# Altium schematic sheet H-9220 Backplane Board - DUT Bd9-10 (REV07).SchDoc
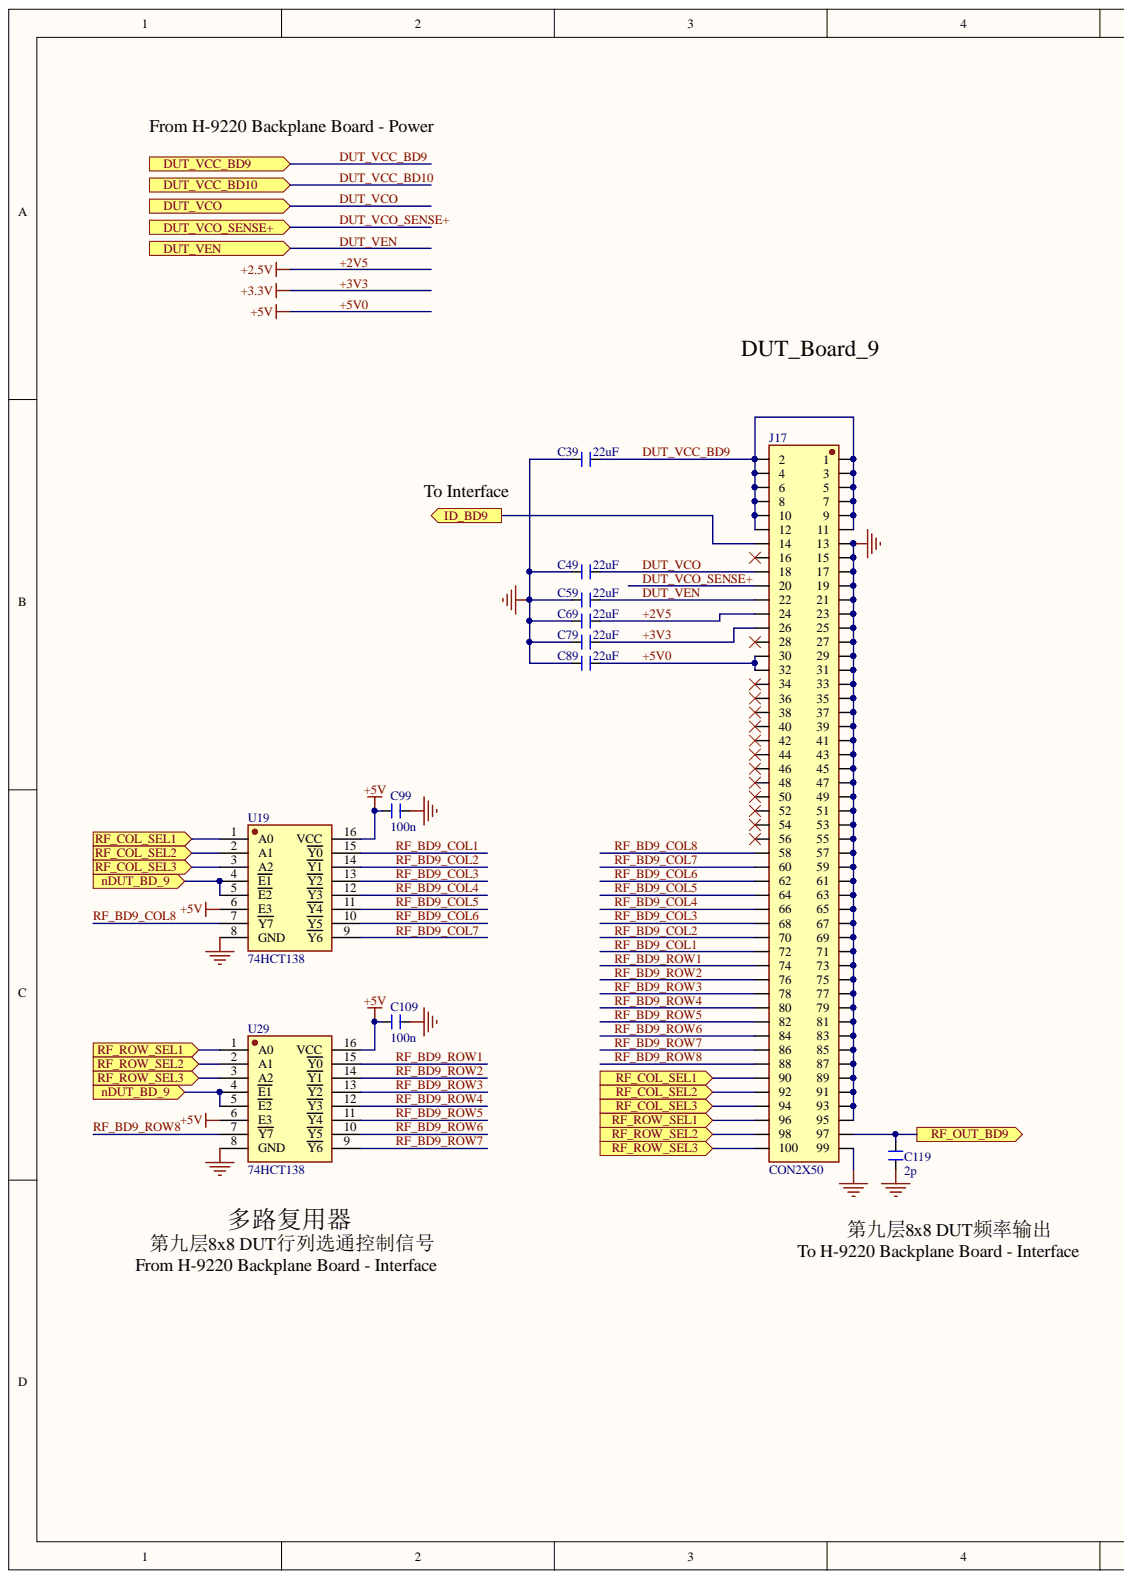
[diagram p0: H-9220 Backplane Board - DUT Bd9-10 (REV07).SchDoc, left]
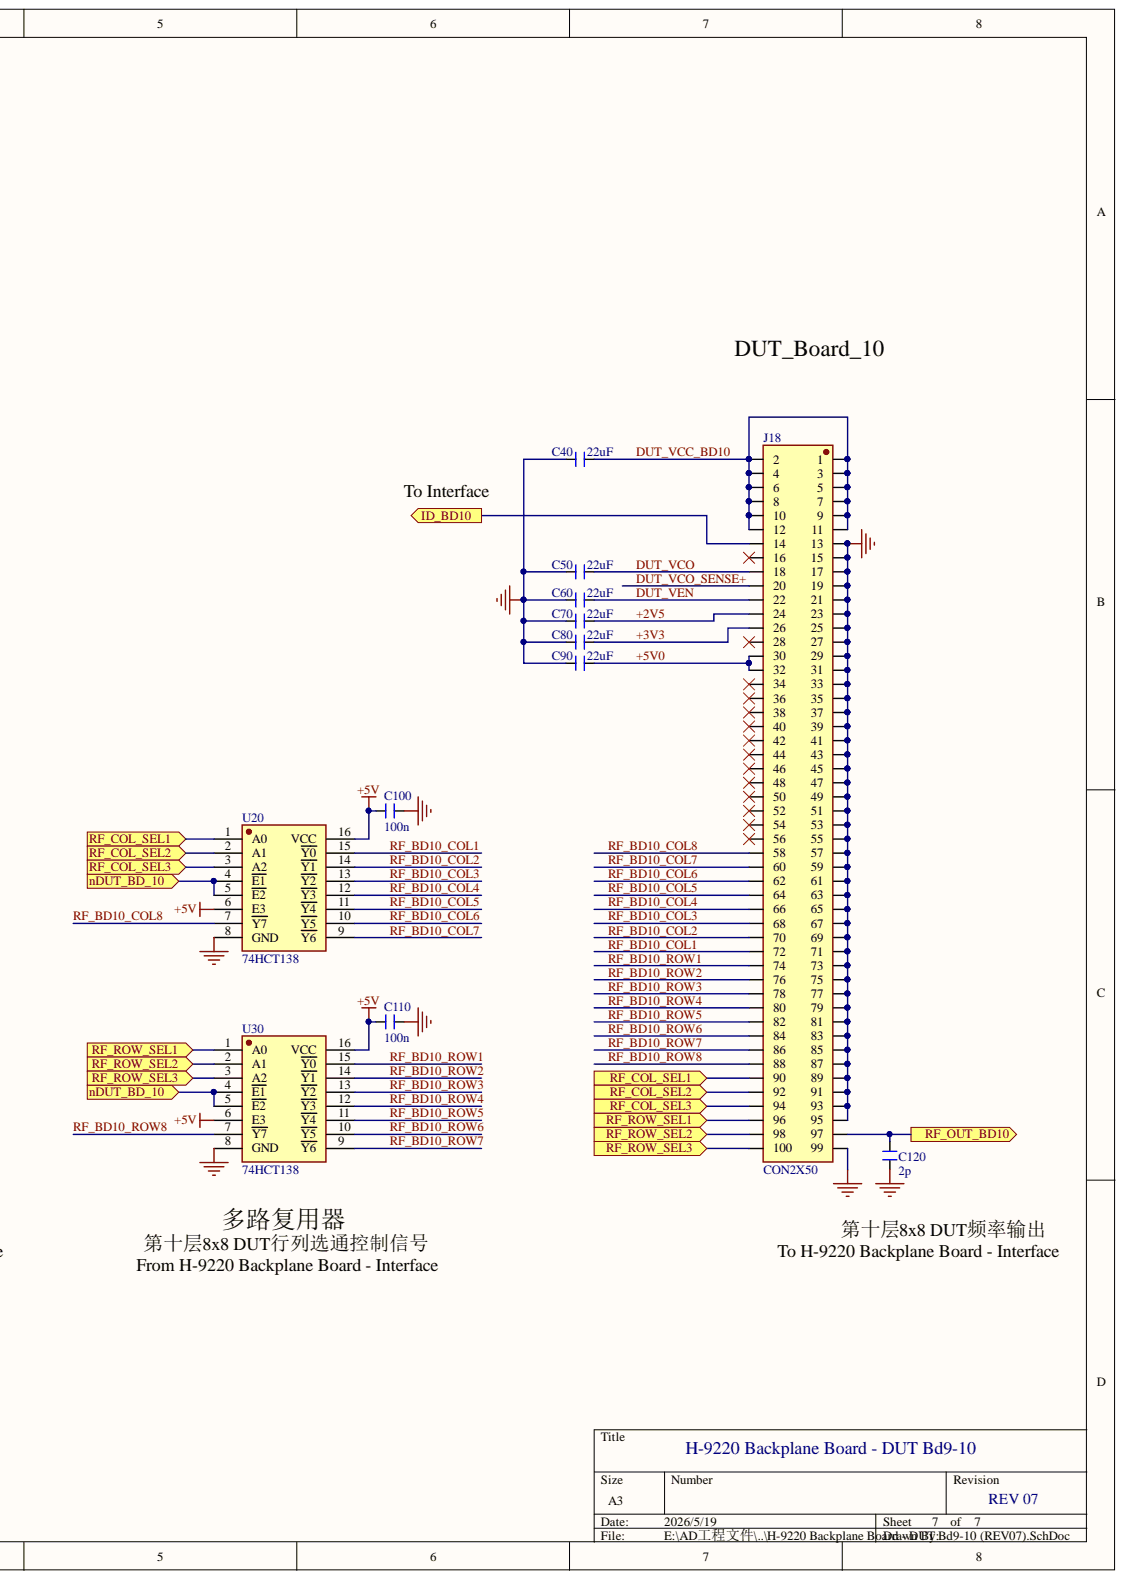
[diagram p1: H-9220 Backplane Board - DUT Bd9-10 (REV07).SchDoc, right]

## Parts
C39  22uF  [C-1206]
C40  22uF  [C-1206]
C49  22uF  [C-1206]
C50  22uF  [C-1206]
C59  22uF  [C-1206]
C60  22uF  [C-1206]
C69  22uF  [C-1206]
C70  22uF  [C-1206]
C79  22uF  [C-1206]
C80  22uF  [C-1206]
C89  22uF  [C-1206]
C90  22uF  [C-1206]
C99  100n  [C-0603]
C100  100n  [C-0603]
C109  100n  [C-0603]
C110  100n  [C-0603]
C119  2p  [C-0603]
C120  2p  [C-0603]
J17  CON2X50  [SFML-150-01-S-D-LC]
J18  CON2X50  [SFML-150-01-S-D-LC]
U19  74HCT138  [SOIC-16 (BODY=3.9MM)]
U20  74HCT138  [SOIC-16 (BODY=3.9MM)]
U29  74HCT138  [SOIC-16 (BODY=3.9MM)]
U30  74HCT138  [SOIC-16 (BODY=3.9MM)]

## Ports
DUT_VCC_BD9, DUT_VCC_BD10, DUT_VCO, DUT_VCO_SENSE+, DUT_VEN, ID_BD9, ID_BD10, nDUT_BD_9, nDUT_BD_10, RF_COL_SEL1, RF_COL_SEL2, RF_COL_SEL3, RF_OUT_BD9, RF_OUT_BD10, RF_ROW_SEL1, RF_ROW_SEL2, RF_ROW_SEL3

## Nets
GND: C39.2 C40.2 C49.2 C50.2 C59.2 C60.2 C69.2 C70.2 C79.2 C80.2 C89.2 C90.2 C99.2 C100.2 C109.2 C110.2 C119.2 C120.2 J17.13 J17.15 J17.17 J17.19 J17.21 J17.23 J17.25 J17.27 J17.29 J17.31 J17.33 J17.35 J17.37 J17.39 J17.41 J17.43 J17.45 J17.47 J17.49 J17.51 J17.53 J17.55 J17.57 J17.59 J17.61 J17.63 J17.65 J17.67 J17.69 J17.71 J17.73 J17.75 J17.77 J17.79 J17.81 J17.83 J17.85 J17.87 J17.89 J17.91 J17.93 J17.95 J17.99 J18.13 J18.15 J18.17 J18.19 J18.21 J18.23 J18.25 J18.27 J18.29 J18.31 J18.33 J18.35 J18.37 J18.39 J18.41 J18.43 J18.45 J18.47 J18.49 J18.51 J18.53 J18.55 J18.57 J18.59 J18.61 J18.63 J18.65 J18.67 J18.69 J18.71 J18.73 J18.75 J18.77 J18.79 J18.81 J18.83 J18.85 J18.87 J18.89 J18.91 J18.93 J18.95 J18.99 U19.8(GND) U20.8(GND) U29.8(GND) U30.8(GND)
+2V5: C69.1 C70.1 J17.24 J18.24
+3V3: C79.1 C80.1 J17.26 J18.26
+5V0: C89.1 C90.1 C99.1 C100.1 C109.1 C110.1 J17.30 J17.32 J18.30 J18.32 U19.6(E3) U19.16(VCC) U20.6(E3) U20.16(VCC) U29.6(E3) U29.16(VCC) U30.6(E3) U30.16(VCC)
DUT_VCC_BD9: C39.1 J17.1 J17.2 J17.3 J17.4 J17.5 J17.6 J17.7 J17.8 J17.9 J17.10 J17.11 J17.12 ; port DUT_VCC_BD9
DUT_VCC_BD10: C40.1 J18.1 J18.2 J18.3 J18.4 J18.5 J18.6 J18.7 J18.8 J18.9 J18.10 J18.11 J18.12 ; port DUT_VCC_BD10
DUT_VCO: C49.1 C50.1 J17.18 J18.18 ; port DUT_VCO
DUT_VCO_SENSE+: J17.20 J18.20 ; port DUT_VCO_SENSE+
DUT_VEN: C59.1 C60.1 J17.22 J18.22 ; port DUT_VEN
ID_BD9: J17.14 ; port ID_BD9
ID_BD10: J18.14 ; port ID_BD10
nDUT_BD_9: U19.4(E1) U19.5(E2) U29.4(E1) U29.5(E2) ; port nDUT_BD_9
nDUT_BD_10: U20.4(E1) U20.5(E2) U30.4(E1) U30.5(E2) ; port nDUT_BD_10
RF_BD9_COL1: J17.72 U19.15(Y0)
RF_BD9_COL2: J17.70 U19.14(Y1)
RF_BD9_COL3: J17.68 U19.13(Y2)
RF_BD9_COL4: J17.66 U19.12(Y3)
RF_BD9_COL5: J17.64 U19.11(Y4)
RF_BD9_COL6: J17.62 U19.10(Y5)
RF_BD9_COL7: J17.60 U19.9(Y6)
RF_BD9_COL8: J17.58 U19.7(Y7)
RF_BD9_ROW1: J17.74 U29.15(Y0)
RF_BD9_ROW2: J17.76 U29.14(Y1)
RF_BD9_ROW3: J17.78 U29.13(Y2)
RF_BD9_ROW4: J17.80 U29.12(Y3)
RF_BD9_ROW5: J17.82 U29.11(Y4)
RF_BD9_ROW6: J17.84 U29.10(Y5)
RF_BD9_ROW7: J17.86 U29.9(Y6)
RF_BD9_ROW8: J17.88 U29.7(Y7)
RF_BD10_COL1: J18.72 U20.15(Y0)
RF_BD10_COL2: J18.70 U20.14(Y1)
RF_BD10_COL3: J18.68 U20.13(Y2)
RF_BD10_COL4: J18.66 U20.12(Y3)
RF_BD10_COL5: J18.64 U20.11(Y4)
RF_BD10_COL6: J18.62 U20.10(Y5)
RF_BD10_COL7: J18.60 U20.9(Y6)
RF_BD10_COL8: J18.58 U20.7(Y7)
RF_BD10_ROW1: J18.74 U30.15(Y0)
RF_BD10_ROW2: J18.76 U30.14(Y1)
RF_BD10_ROW3: J18.78 U30.13(Y2)
RF_BD10_ROW4: J18.80 U30.12(Y3)
RF_BD10_ROW5: J18.82 U30.11(Y4)
RF_BD10_ROW6: J18.84 U30.10(Y5)
RF_BD10_ROW7: J18.86 U30.9(Y6)
RF_BD10_ROW8: J18.88 U30.7(Y7)
RF_COL_SEL1: J17.90 J18.90 U19.1(A0) U20.1(A0) ; port RF_COL_SEL1
RF_COL_SEL2: J17.92 J18.92 U19.2(A1) U20.2(A1) ; port RF_COL_SEL2
RF_COL_SEL3: J17.94 J18.94 U19.3(A2) U20.3(A2) ; port RF_COL_SEL3
RF_OUT_BD9: C119.1 J17.97 ; port RF_OUT_BD9
RF_OUT_BD10: C120.1 J18.97 ; port RF_OUT_BD10
RF_ROW_SEL1: J17.96 J18.96 U29.1(A0) U30.1(A0) ; port RF_ROW_SEL1
RF_ROW_SEL2: J17.98 J18.98 U29.2(A1) U30.2(A1) ; port RF_ROW_SEL2
RF_ROW_SEL3: J17.100 J18.100 U29.3(A2) U30.3(A2) ; port RF_ROW_SEL3

Not connected: J17 16 28 34 36 38 40 42 44 46 48 50 52 54 56; J18 16 28 34 36 38 40 42 44 46 48 50 52 54 56

## Library data of the parts
C39 C40 C49 C50 C59 C60 C69 C70 C79 C80 C89 C90 C99 C100 C109 C110 C119 C120 = CAP: Component Kind: Standard; Library Name: HW SCH Lib.SCHLIB
J17 J18 = CON-2x50P: Component Kind: Standard; Library Name: HW SCH Lib.SCHLIB
U19 U20 U29 U30 = 74HCT138: Component Kind: Standard; Library Name: HW SCH Lib.SCHLIB
